# Altium schematic sheet [08] - HDMI.SchDoc("[08] - HDMI")
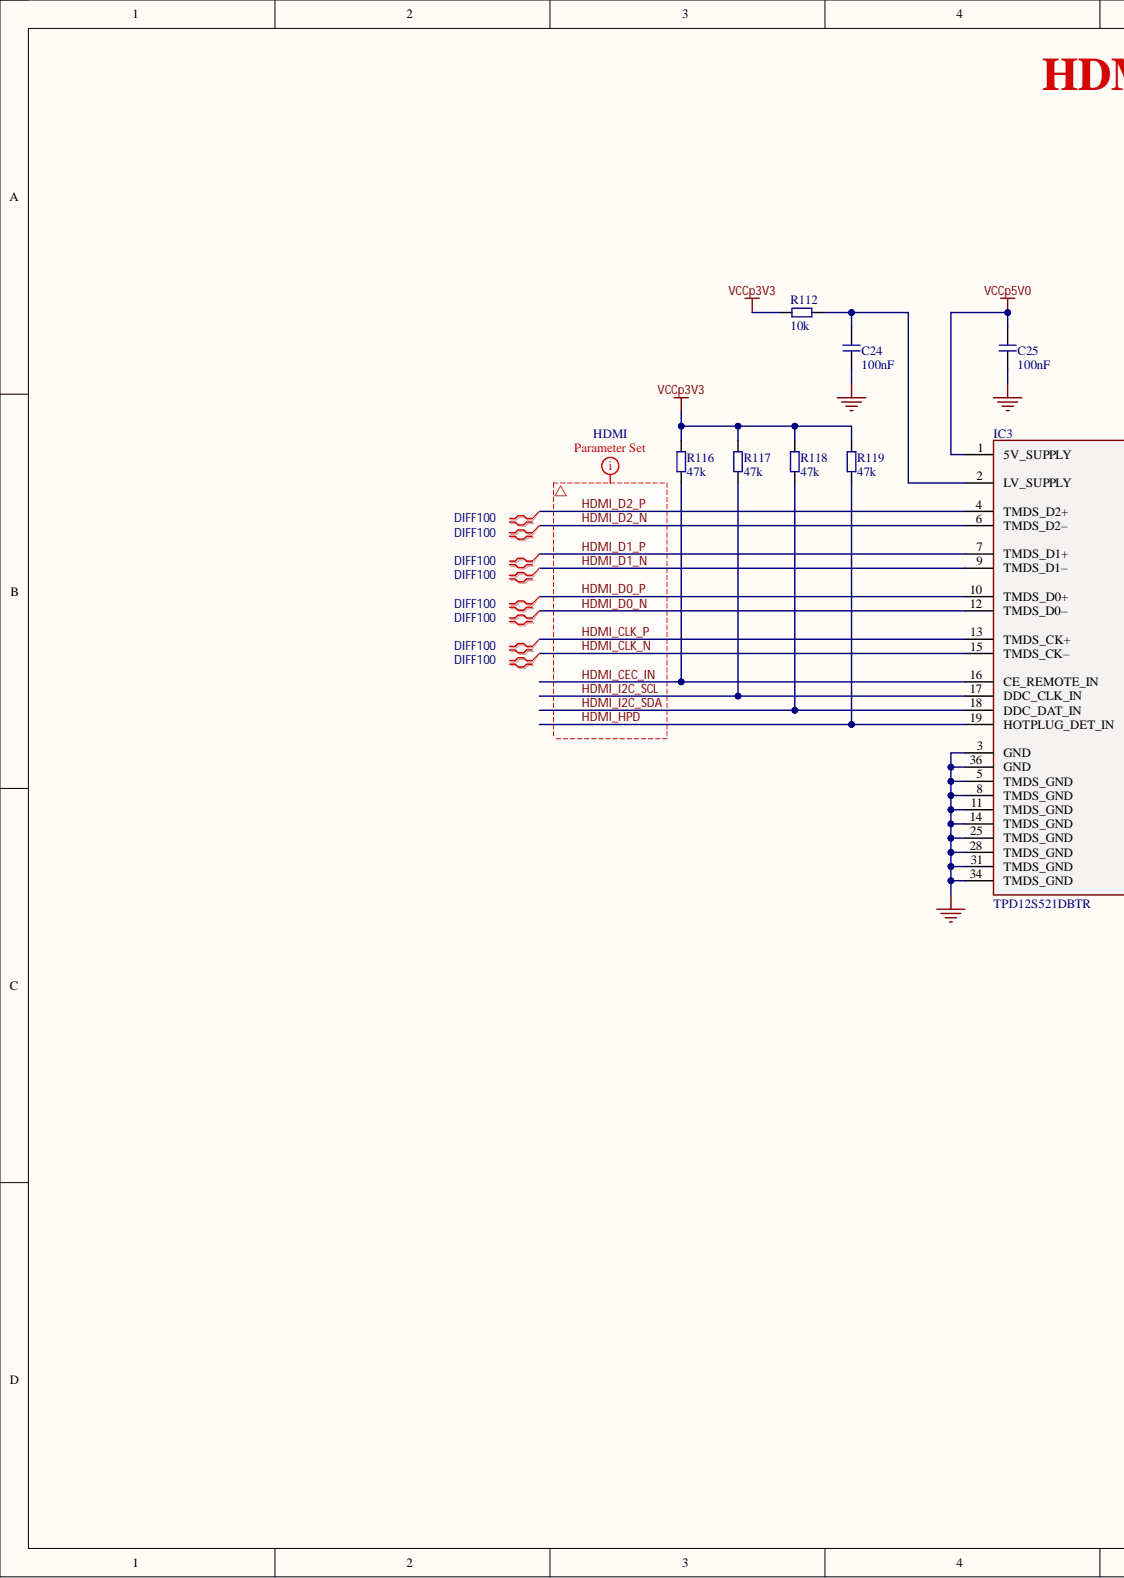
[diagram p0: [08] - HDMI.SchDoc, left]
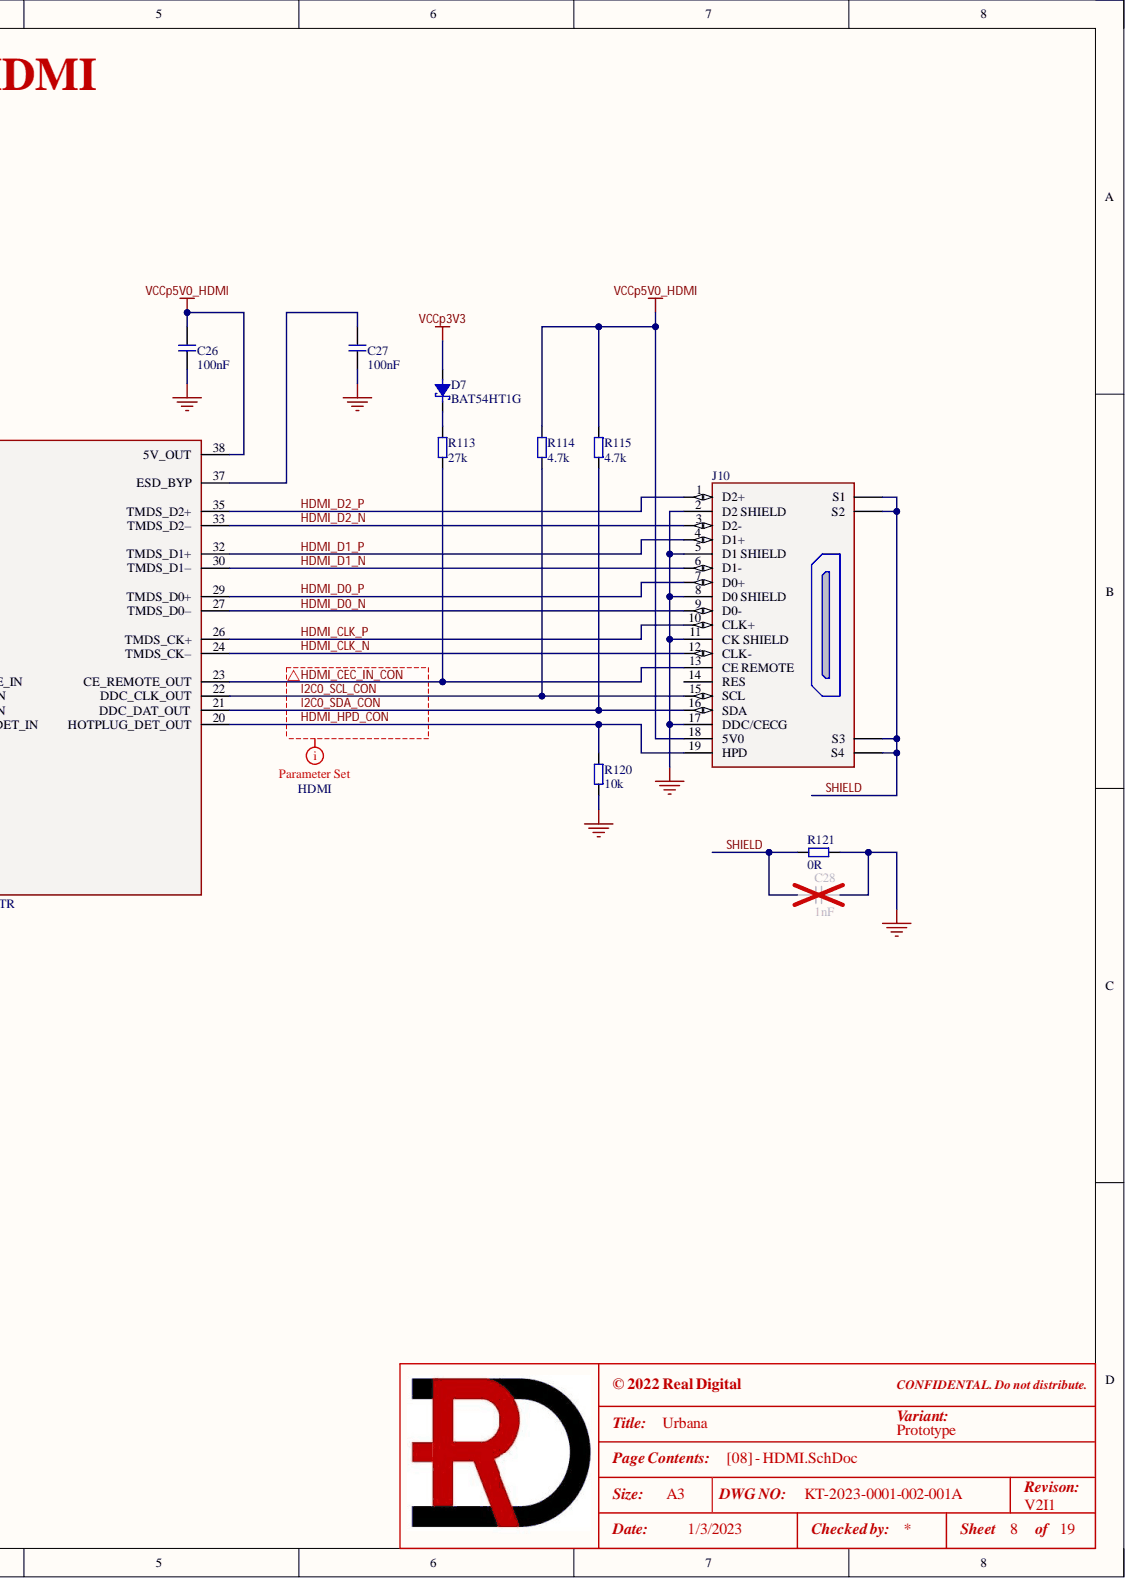
[diagram p1: [08] - HDMI.SchDoc, right]

## Parts
C24  100nF  [C0402]
C25  100nF  [C0402]
C26  100nF  [C0402]
C27  100nF  [C0402]
C28  1nF  [C0805]
D7  BAT54HT1G  [SOD-323 - ONSEMI]
IC3  TPD12S521DBTR  [DBT0038A_TFSOP-38 - TI]
J10  10029449-111RLF  [10029449-111RLF]  (value not drawn)
R112  10k  [R0402]
R113  27k  [R0402]
R114  4.7k  [R0402]
R115  4.7k  [R0402]
R116  47k  [R0402]
R117  47k  [R0402]
R118  47k  [R0402]
R119  47k  [R0402]
R120  10k  [R0402]
R121  0R  [R0805]

## Nets
GND: C24.1 C25.1 C26.1 C27.1 C28.2 IC3.3(GND) IC3.5(TMDS_GND) IC3.8(TMDS_GND) IC3.11(TMDS_GND) IC3.14(TMDS_GND) IC3.25(TMDS_GND) IC3.28(TMDS_GND) IC3.31(TMDS_GND) IC3.34(TMDS_GND) IC3.36(GND) J10.2(D2_SHIELD) J10.5(D1_SHIELD) J10.8(D0_SHIELD) J10.11(CK_SHIELD) J10.17(DDC/CECG) R120.1 R121.2
VCCp3V3: D7.A R112.1 R116.2 R117.2 R118.2 R119.2
VCCp5V0: C25.2 IC3.1(5V_SUPPLY)
VCCp5V0_HDMI: C26.2 IC3.38(5V_OUT) J10.18(5V0) R114.2 R115.2
HDMI_CEC_IN: IC3.16(CE_REMOTE_IN) R116.1
HDMI_CEC_IN_CON: IC3.23(CE_REMOTE_OUT) J10.13(CE_REMOTE) R113.1
HDMI_CLK_N: IC3.15(TMDS_CK–) IC3.24(TMDS_CK–) J10.12(CLK-)
HDMI_CLK_P: IC3.13(TMDS_CK+) IC3.26(TMDS_CK+) J10.10(CLK+)
HDMI_D0_N: IC3.12(TMDS_D0–) IC3.27(TMDS_D0–) J10.9(D0-)
HDMI_D0_P: IC3.10(TMDS_D0+) IC3.29(TMDS_D0+) J10.7(D0+)
HDMI_D1_N: IC3.9(TMDS_D1–) IC3.30(TMDS_D1–) J10.6(D1-)
HDMI_D1_P: IC3.7(TMDS_D1+) IC3.32(TMDS_D1+) J10.4(D1+)
HDMI_D2_N: IC3.6(TMDS_D2–) IC3.33(TMDS_D2–) J10.3(D2-)
HDMI_D2_P: IC3.4(TMDS_D2+) IC3.35(TMDS_D2+) J10.1(D2+)
HDMI_HPD: IC3.19(HOTPLUG_DET_IN) R119.1
HDMI_HPD_CON: IC3.20(HOTPLUG_DET_OUT) J10.19(HPD) R120.2
HDMI_I2C_SCL: IC3.17(DDC_CLK_IN) R117.1
HDMI_I2C_SDA: IC3.18(DDC_DAT_IN) R118.1
I2C0_SCL_CON: IC3.22(DDC_CLK_OUT) J10.15(SCL) R114.1
I2C0_SDA_CON: IC3.21(DDC_DAT_OUT) J10.16(SDA) R115.1
SHIELD: C28.1 J10.S1 J10.S2 J10.S3 J10.S4 R121.1
NetC24_2: C24.2 IC3.2(LV_SUPPLY) R112.2
NetC27_2: C27.2 IC3.37(ESD_BYP)
NetD7_K: D7.K R113.2

Not connected: J10 14(RES)

## Library data of the parts
C24-C27 = GRM155R71E104KE14J: CAP CER 0.1uF 25V X7R 0402; Manufacturer 1: Murata Electronics; .Checked_PCB: AC; .Creator: RV; C P/N: RD-111-0006; LoadStatus: Load; Tolerance: 10%; Category: Capacitors; Component Kind: Standard; Library Name: KT-RealDigital.IntLib; Manufacturer 1 Part Number: GRM155R71E104KE14J; Temperature Coefficient: X7R; Voltage - Rated: 25V; .Checked_SCH: AC
C28 = GCJ21AR72E102KXJ1D: CAP CER 1nF 250V X7R 0805; Manufacturer 1: Murata Electronics; .Checked_PCB: RV; LoadStatus: Load; Tolerance: ±10%; Category: Capacitors; Component Kind: Standard; Library Name: KT-RealDigital.IntLib; Manufacturer 1 Part Number: GCJ21AR72E102KXJ1D; Temperature Coefficient: X7R; Voltage - Rated: 250V; .Checked_SCH: RV; .Creator: RV
D7 = BAT54HT1G: DIODE SCHOTTKY 30V 200MA SOD323; Manufacturer 1: ON Semiconductor; .Checked_PCB: AC; .Creator: RV; C P/N: 121-0304; LoadStatus: Load; Category: Diodes; Component Kind: Standard; Library Name: KT-RealDigital.IntLib; Manufacturer 1 Part Number: BAT54HT1G; .Checked_SCH: AC
IC3 = TPD12S521DBTR: Specialised HDMI RECEIVER PORT PROTECTION; Manufacturer 1: Texas Instruments; .Checked_PCB: AC; .Creator: RV; C P/N: RD-126-0002; LoadStatus: Load; Category: IC; Component Kind: Standard; Library Name: KT-RealDigital.IntLib; Manufacturer 1 Part Number: TPD12S521DBTR; .Checked_SCH: AC
J10 = 10029449-111RLF: CONN RCPT 1.4 HDMI 19POS SMD R/A; Manufacturer 1: Amphenol ICC (FCI); .Checked_PCB: AC; .Creator: RV; C P/N: RD-161-0001; LoadStatus: Load; Category: Connectors; Component Kind: Standard; Library Name: KT-RealDigital.IntLib; Manufacturer 1 Part Number: 10029449-111RLF; .Checked_SCH: AC
R112 R120 = RC0402JR-0710KL: RES SMD 10K OHM 5% 1/16W 0402; Manufacturer 1: Yageo; .Checked_PCB: AC; .Creator: RV; C P/N: 101-0047; LoadStatus: Load; Category: Resistors; Component Kind: Standard; Library Name: KT-RealDigital.IntLib; Manufacturer 1 Part Number: RC0402JR-0710KL; Tolerance: 5%; .Checked_SCH: AC
R113 = RC0402JR-0727KL: RES SMD 27K OHM 5% 1/16W 0402; Manufacturer 1: Yageo; .Checked_PCB: AC; .Creator: RV; C P/N: 101-0802; LoadStatus: Load; Category: Resistors; Component Kind: Standard; Library Name: KT-RealDigital.IntLib; Manufacturer 1 Part Number: RC0402JR-0727KL; Tolerance: 5%; .Checked_SCH: AC
R114 R115 = RC0402FR-074K7P: RES SMD 4.7K OHM 1% 1/16W 0402; Manufacturer 1: Yageo; .Checked_PCB: AC; .Creator: RV; C P/N: 101-0129; LoadStatus: Load; Category: Resistors; Component Kind: Standard; Library Name: KT-RealDigital.IntLib; Manufacturer 1 Part Number: RC0402FR-074K7P; Tolerance: 1%; .Checked_SCH: AC
R116-R119 = RC0402JR-0747KL: RES SMD 47K OHM 5% 1/16W 0402; Manufacturer 1: Yageo; .Checked_PCB: AC; .Creator: RV; C P/N: 101-0074; LoadStatus: Load; Category: Resistors; Component Kind: Standard; Library Name: KT-RealDigital.IntLib; Manufacturer 1 Part Number: RC0402JR-0747KL; Tolerance: 5%; .Checked_SCH: AC
R121 = RC0805JR-070RL: RES SMD 0 OHM JUMPER 1/8W 0805; Manufacturer 1: Yageo; .Checked_PCB: AC; .Creator: RV; LoadStatus: Load; Category: Resistors; Component Kind: Standard; Library Name: KT-RealDigital.IntLib; Manufacturer 1 Part Number: RC0805JR-070RL; Tolerance: Jumper; .Checked_SCH: AC
